# Altium schematic sheet RemoteController.SchDoc
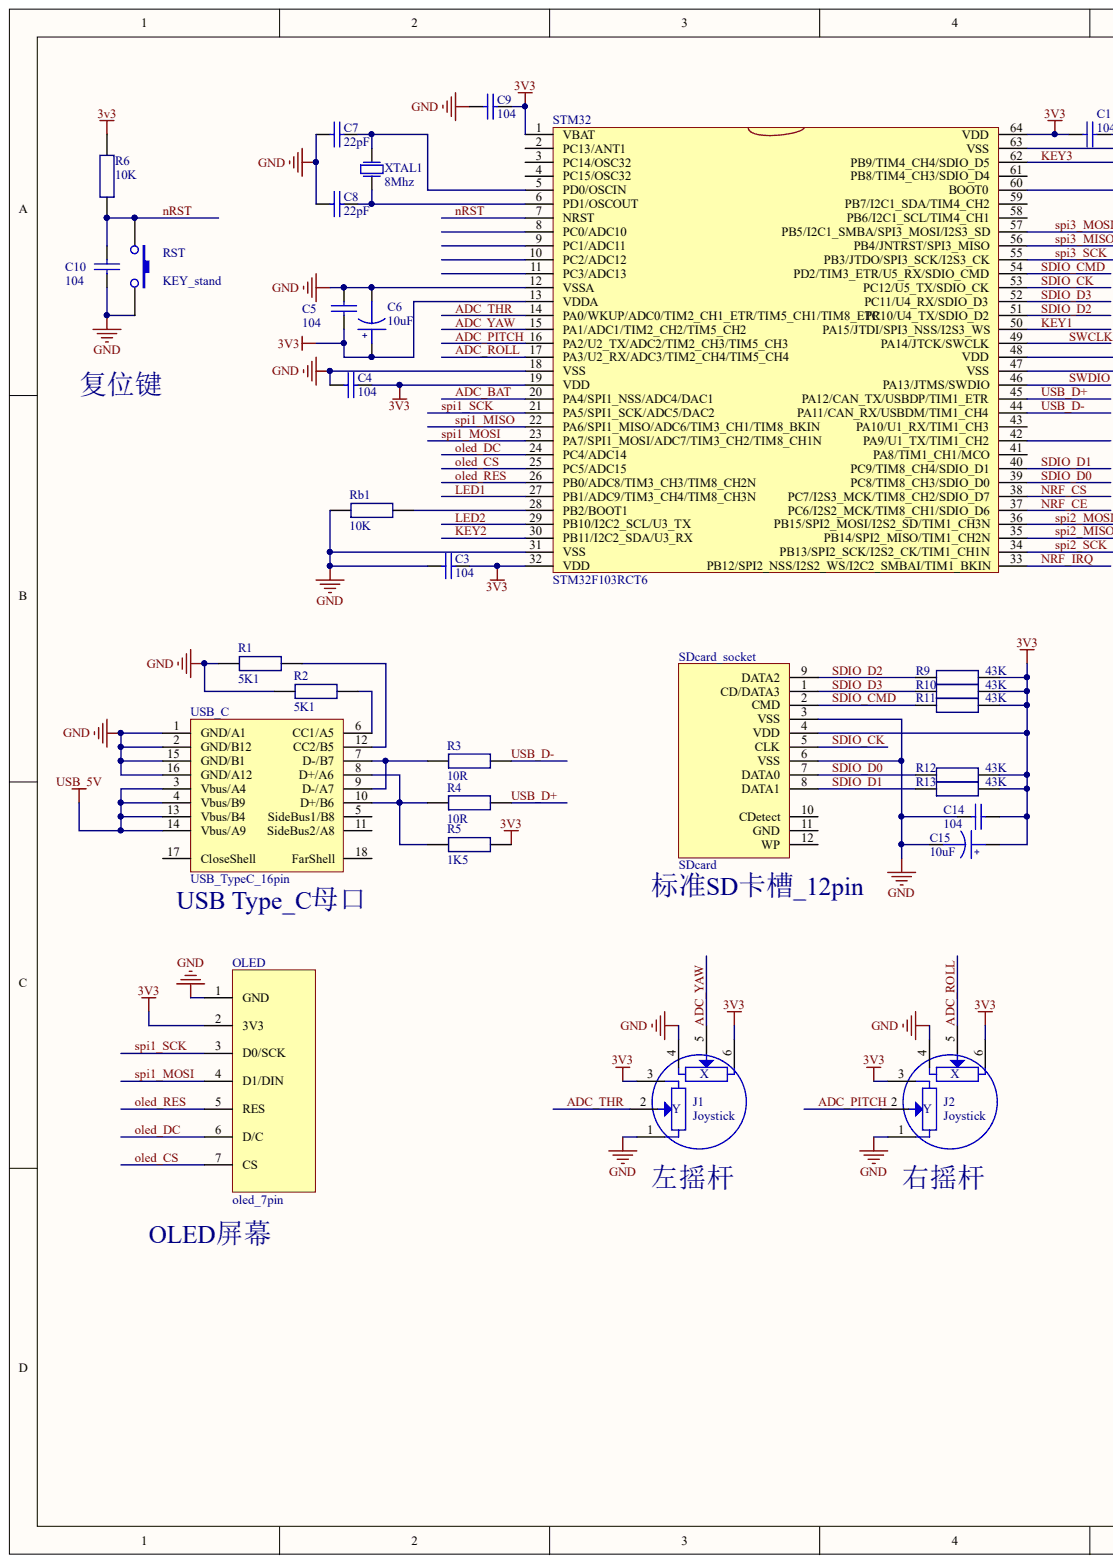
[diagram p0: RemoteController.SchDoc, left]
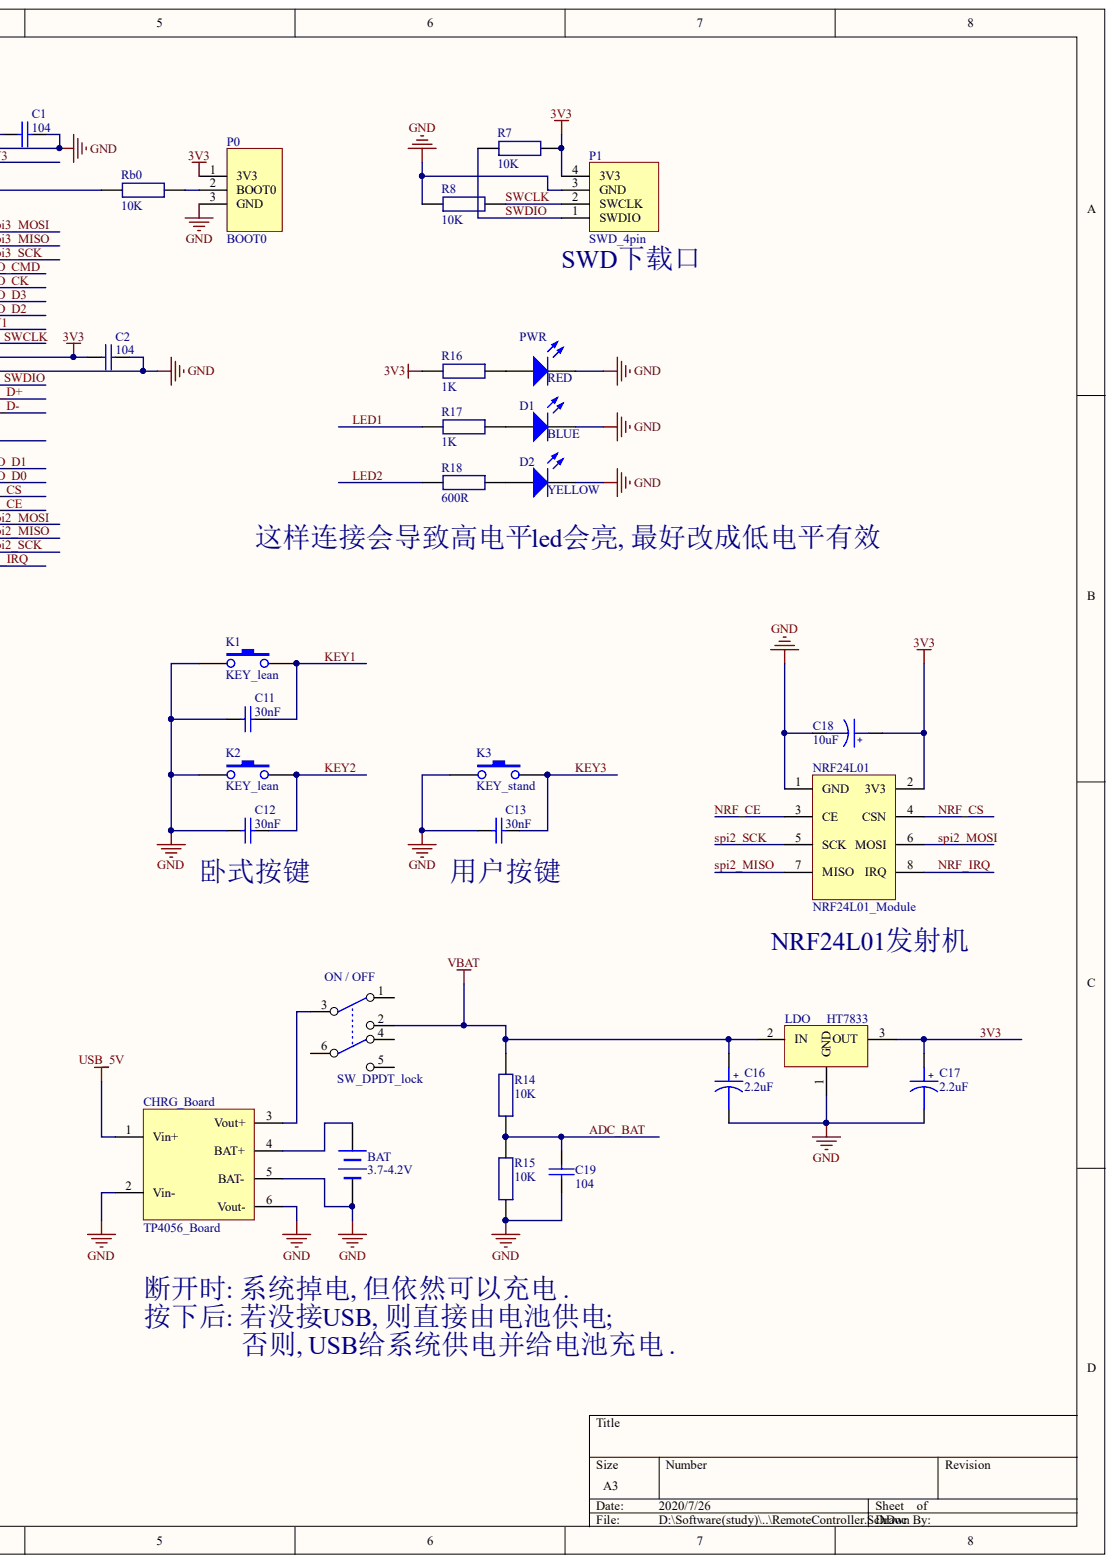
[diagram p1: RemoteController.SchDoc, right]

## Parts
BAT  3.7-4.2V  [XH2.54mm]
C1  104  [RAD0.1]
C2  104  [RAD0.1]
C3  104  [RAD0.1]
C4  104  [RAD0.1]
C5  104  [RAD0.1]
C6  10uF  [RB.1/.2]
C7  22pF  [RAD0.1]
C8  22pF  [RAD0.1]
C9  104  [RAD0.1]
C10  104  [RAD0.1]
C11  30nF  [RAD0.1]
C12  30nF  [RAD0.1]
C13  30nF  [RAD0.1]
C14  104  [RAD0.1]
C15  10uF  [RB.1/.2]
C16  2.2uF  [RB.1/.2]
C17  2.2uF  [RB.1/.2]
C18  10uF  [RB.1/.2]
C19  104  [RAD0.1]
CHRG_Board  TP4056_Board  [TP4056_BOARD]
D1  BLUE  [LED_0805]
D2  YELLOW  [LED_0805]
J1  Joystick  [Joystick]
J2  Joystick  [Joystick]
K1  KEY_lean  [KEY_lean]
K2  KEY_lean  [KEY_lean]
K3  KEY_stand  [KEY_stand]
LDO  HT7833  [SOT-89-431]
NRF24L01  NRF24L01_Module  [NRF24L01_SMA_ANT]
OLED  oled_7pin  [OLED_7PIN]
ON_/_OFF  SW_DPDT_lock  [SW_DPDT_lock]
P0  BOOT0  [BOOT0]
P1  SWD_4pin  [SWD_4pin]
PWR  RED  [LED_0805]
R1  5K1  [RES_0805]
R2  5K1  [RES_0805]
R3  10R  [RES_0805]
R4  10R  [RES_0805]
R5  1K5  [RES_0805]
R6  10K  [RES_0805]
R7  10K  [RES_0805]
R8  10K  [RES_0805]
R9  43K  [RES_0805]
R10  43K  [RES_0805]
R11  43K  [RES_0805]
R12  43K  [RES_0805]
R13  43K  [RES_0805]
R14  10K  [RES_0805]
R15  10K  [RES_0805]
R16  1K  [RES_0805]
R17  1K  [RES_0805]
R18  600R  [RES_0805]
Rb0  10K  [RES_0805]
Rb1  10K  [RES_0805]
RST  KEY_stand  [KEY_stand]
SDcard_socket  SDcard  [SDCARD]
STM32  STM32F103RCT6  [STM-LQFP64_M]
USB_C  USB_TypeC_16pin  [USB_TypeC_16pin]
XTAL1  8Mhz  [XTAL-49/S_N]

## Nets
GND: BAT.2 C1.2 C2.2 C3.1 C4.1 C5.2 C6.2 C7.1 C8.1 C9.1 C10.2 C11.1 C12.1 C13.1 C14.1 C15.2 C16.2 C17.2 C18.2 C19.1 CHRG_Board.2(Vin-) CHRG_Board.5(BAT-) CHRG_Board.6(Vout-) D1.2 D2.2 J1.1 J1.4 J2.1 J2.4 K1.1 K2.1 K3.1 LDO.1 NRF24L01.1(GND) OLED.1(GND) P0.3 P1.3(GND) PWR.2 R1.1 R2.1 R8.1 R15.1 Rb1.1 RST.2 SDcard_socket.3(VSS) SDcard_socket.6(VSS) STM32.12(VSSA) STM32.18(VSS) STM32.31(VSS) STM32.47(VSS) STM32.63(VSS) USB_C.1(GND/A1) USB_C.2(GND/B12) USB_C.15(GND/B1) USB_C.16(GND/A12)
USB_5V: CHRG_Board.1(Vin+) USB_C.3(Vbus/A4) USB_C.4(Vbus/B9) USB_C.13(Vbus/B4) USB_C.14(Vbus/A9)
VBAT: C16.1 LDO.2 ON_/_OFF.2 R14.2
3V3: C1.1 C2.1 C3.2 C4.2 C5.1 C6.1 C9.2 C14.2 C15.1 C17.1 C18.1 J1.3 J1.6 J2.3 J2.6 LDO.3 NRF24L01.2(3V3) OLED.2(3V3) P0.1 P1.4(3V3) R5.2 R6.2 R7.2 R9.2 R10.2 R11.2 R12.2 R13.2 R16.2 SDcard_socket.4(VDD) STM32.1(VBAT) STM32.13(VDDA) STM32.19(VDD) STM32.32(VDD) STM32.48(VDD) STM32.64(VDD)
ADC_BAT: C19.2 R14.1 R15.2 STM32.20(PA4/SPI1_NSS/ADC4/DAC1)
ADC_PITCH: J2.2(Y) STM32.16(PA2/U2_TX/ADC2/TIM2_CH3/TIM5_CH3)
ADC_ROLL: J2.5(X) STM32.17(PA3/U2_RX/ADC3/TIM2_CH4/TIM5_CH4)
ADC_THR: J1.2(Y) STM32.14(PA0/WKUP/ADC0/TIM2_CH1_ETR/TIM5_CH1/TIM8_ETR)
ADC_YAW: J1.5(X) STM32.15(PA1/ADC1/TIM2_CH2/TIM5_CH2)
KEY1: C11.2 K1.2 STM32.50(PA15/JTDI/SPI3_NSS/I2S3_WS)
KEY2: C12.2 K2.2 STM32.30(PB11/I2C2_SDA/U3_RX)
KEY3: C13.2 K3.2 STM32.62(PB9/TIM4_CH4/SDIO_D5)
LED1: R17.2 STM32.27(PB1/ADC9/TIM3_CH4/TIM8_CH3N)
LED2: R18.2 STM32.29(PB10/I2C2_SCL/U3_TX)
NRF_CE: NRF24L01.3(CE) STM32.37(PC6/I2S2_MCK/TIM8_CH1/SDIO_D6)
NRF_CS: NRF24L01.4(CSN) STM32.38(PC7/I2S3_MCK/TIM8_CH2/SDIO_D7)
NRF_IRQ: NRF24L01.8(IRQ) STM32.33(PB12/SPI2_NSS/I2S2_WS/I2C2_SMBAI/TIM1_BKIN)
NRST: C10.1 R6.1 RST.1 STM32.7(NRST)
OLED_CS: OLED.7(CS) STM32.25(PC5/ADC15)
OLED_DC: OLED.6(D/C) STM32.24(PC4/ADC14)
OLED_RES: OLED.5(RES) STM32.26(PB0/ADC8/TIM3_CH3/TIM8_CH2N)
SDIO_CK: SDcard_socket.5(CLK) STM32.53(PC12/U5_TX/SDIO_CK)
SDIO_CMD: R11.1 SDcard_socket.2(CMD) STM32.54(PD2/TIM3_ETR/U5_RX/SDIO_CMD)
SDIO_D0: R12.1 SDcard_socket.7(DATA0) STM32.39(PC8/TIM8_CH3/SDIO_D0)
SDIO_D1: R13.1 SDcard_socket.8(DATA1) STM32.40(PC9/TIM8_CH4/SDIO_D1)
SDIO_D2: R9.1 SDcard_socket.9(DATA2) STM32.51(PC10/U4_TX/SDIO_D2)
SDIO_D3: R10.1 SDcard_socket.1(CD/DATA3) STM32.52(PC11/U4_RX/SDIO_D3)
SPI1_MISO: STM32.22(PA6/SPI1_MISO/ADC6/TIM3_CH1/TIM8_BKIN)
SPI1_MOSI: OLED.4(D1/DIN) STM32.23(PA7/SPI1_MOSI/ADC7/TIM3_CH2/TIM8_CH1N)
SPI1_SCK: OLED.3(D0/SCK) STM32.21(PA5/SPI1_SCK/ADC5/DAC2)
SPI2_MISO: NRF24L01.7(MISO) STM32.35(PB14/SPI2_MISO/TIM1_CH2N)
SPI2_MOSI: NRF24L01.6(MOSI) STM32.36(PB15/SPI2_MOSI/I2S2_SD/TIM1_CH3N)
SPI2_SCK: NRF24L01.5(SCK) STM32.34(PB13/SPI2_SCK/I2S2_CK/TIM1_CH1N)
SPI3_MISO: STM32.56(PB4/JNTRST/SPI3_MISO)
SPI3_MOSI: STM32.57(PB5/I2C1_SMBA/SPI3_MOSI/I2S3_SD)
SPI3_SCK: STM32.55(PB3/JTDO/SPI3_SCK/I2S3_CK)
SWCLK: P1.2(SWCLK) R8.2 STM32.49(PA14/JTCK/SWCLK)
SWDIO: P1.1(SWDIO) R7.1 STM32.46(PA13/JTMS/SWDIO)
USB_D+: R4.2 STM32.45(PA12/CAN_TX/USBDP/TIM1_ETR)
USB_D-: R3.2 STM32.44(PA11/CAN_RX/USBDM/TIM1_CH4)
NetBAT_1: BAT.1 CHRG_Board.4(BAT+)
NetC7_2: C7.2 STM32.5(PD0/OSCIN) XTAL1.1
NetC8_2: C8.2 STM32.6(PD1/OSCOUT) XTAL1.2
NetCHRG_Board_3: CHRG_Board.3(Vout+) ON_/_OFF.3
NetD1_1: D1.1 R17.1
NetD2_1: D2.1 R18.1
NetP0_2: P0.2 Rb0.2
NetPWR_1: PWR.1 R16.1
NetR1_2: R1.2 USB_C.12(CC2/B5)
NetR2_2: R2.2 USB_C.6(CC1/A5)
NetR3_1: R3.1 USB_C.7(D-/B7) USB_C.9(D-/A7)
NetR4_1: R4.1 R5.1 USB_C.8(D+/A6) USB_C.10(D+/B6)
NetRb0_1: Rb0.1 STM32.60(BOOT0)
NetRb1_2: Rb1.2 STM32.28(PB2/BOOT1)

Not connected: ON_/_OFF 1 4 5 6; SDcard_socket 10(CDetect) 11(GND) 12(WP); STM32 2(PC13/ANT1) 3(PC14/OSC32) 4(PC15/OSC32) 8(PC0/ADC10) 9(PC1/ADC11) 10(PC2/ADC12) 11(PC3/ADC13) 41(PA8/TIM1_CH1/MCO) 42(PA9/U1_TX/TIM1_CH2) 43(PA10/U1_RX/TIM1_CH3) 58(PB6/I2C1_SCL/TIM4_CH1) 59(PB7/I2C1_SDA/TIM4_CH2) 61(PB8/TIM4_CH3/SDIO_D4); USB_C 5(SideBus1/B8) 11(SideBus2/A8) 17(CloseShell) 18(FarShell)

## Library data of the parts
BAT = Battery_2.54mm: Component Kind: Standard; Library Name: RoboFly.SchLib
C1-C5 C7-C14 C19 = 普通电容: Capacitor; Component Kind: Standard; Library Name: 电容.SCHLIB
C6 C15-C18 = 极性电容: Capacitor; Component Kind: Standard; Library Name: 电容.SCHLIB
CHRG_Board = TP4056_Board: TP4056充电板_28*17 mm; Component Kind: Standard; Library Name: RoboFly.SchLib
D1 D2 PWR = LED: Component Kind: Standard; Library Name: RoboFly.SchLib
K1-K3 RST = KEY: Button; Component Kind: Standard; Library Name: RoboFly.SchLib
OLED = oled_7pin: 7pin_12864_spi; Component Kind: Standard; Library Name: RoboFly.SchLib
ON_/_OFF = SW_DPDT_lock: 双刀双掷_自锁开关_6pin; Component Kind: Standard; Library Name: RoboFly.SchLib
P0 = BOOT0: connect to GND/3V3; Component Kind: Standard; Library Name: RoboFly.SchLib
R1-R18 Rb0 Rb1 = Res: axial_0.4; Component Kind: Standard; Library Name: 自制库元件.SchLib
STM32 = STM32F103RDT6: Component Kind: Standard; Library Name: MiniSTM32 V3.0封装库.IntLib
USB_C = USB_TypeC_16pin: 16pin_单列直插; Component Kind: Standard; Library Name: RoboFly.SchLib
XTAL1 = XTAL_8M: Component Kind: Standard; Library Name: RoboFly.SchLib
J1 J2 = Joystick: Component Kind: Standard; Library Name: RoboFly.SchLib
LDO = HT7833: Component Kind: Standard; Library Name: RoboFly.SchLib
NRF24L01 = NRF24L01_Module: Component Kind: Standard; Library Name: RoboFly.SchLib
P1 = SWD_4pin: Component Kind: Standard; Library Name: RoboFly.SchLib
SDcard_socket = SDcard: Component Kind: Standard; Library Name: RoboFly.SchLib
